# Altium schematic sheet inj_meas.SchDoc
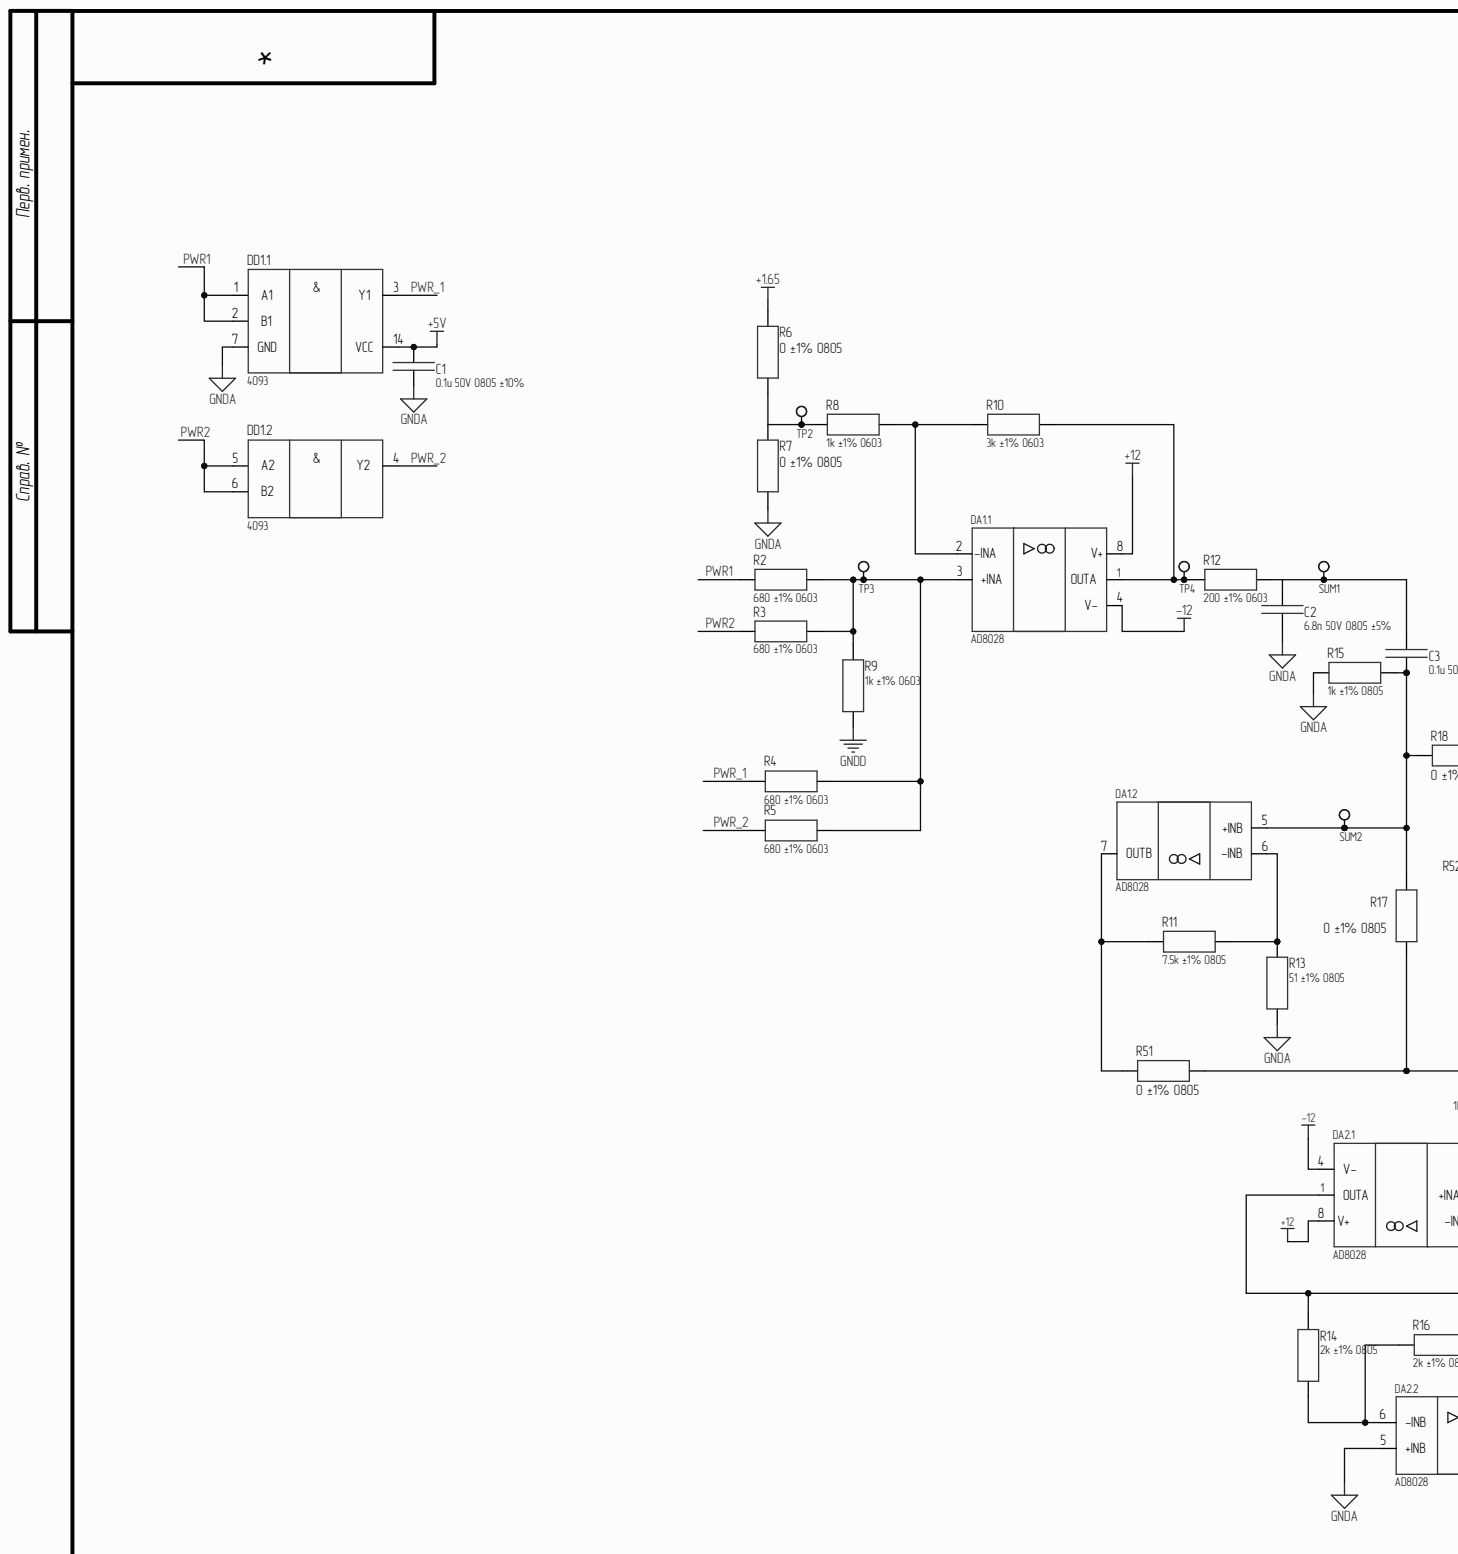
[diagram p0: inj_meas.SchDoc, top left]
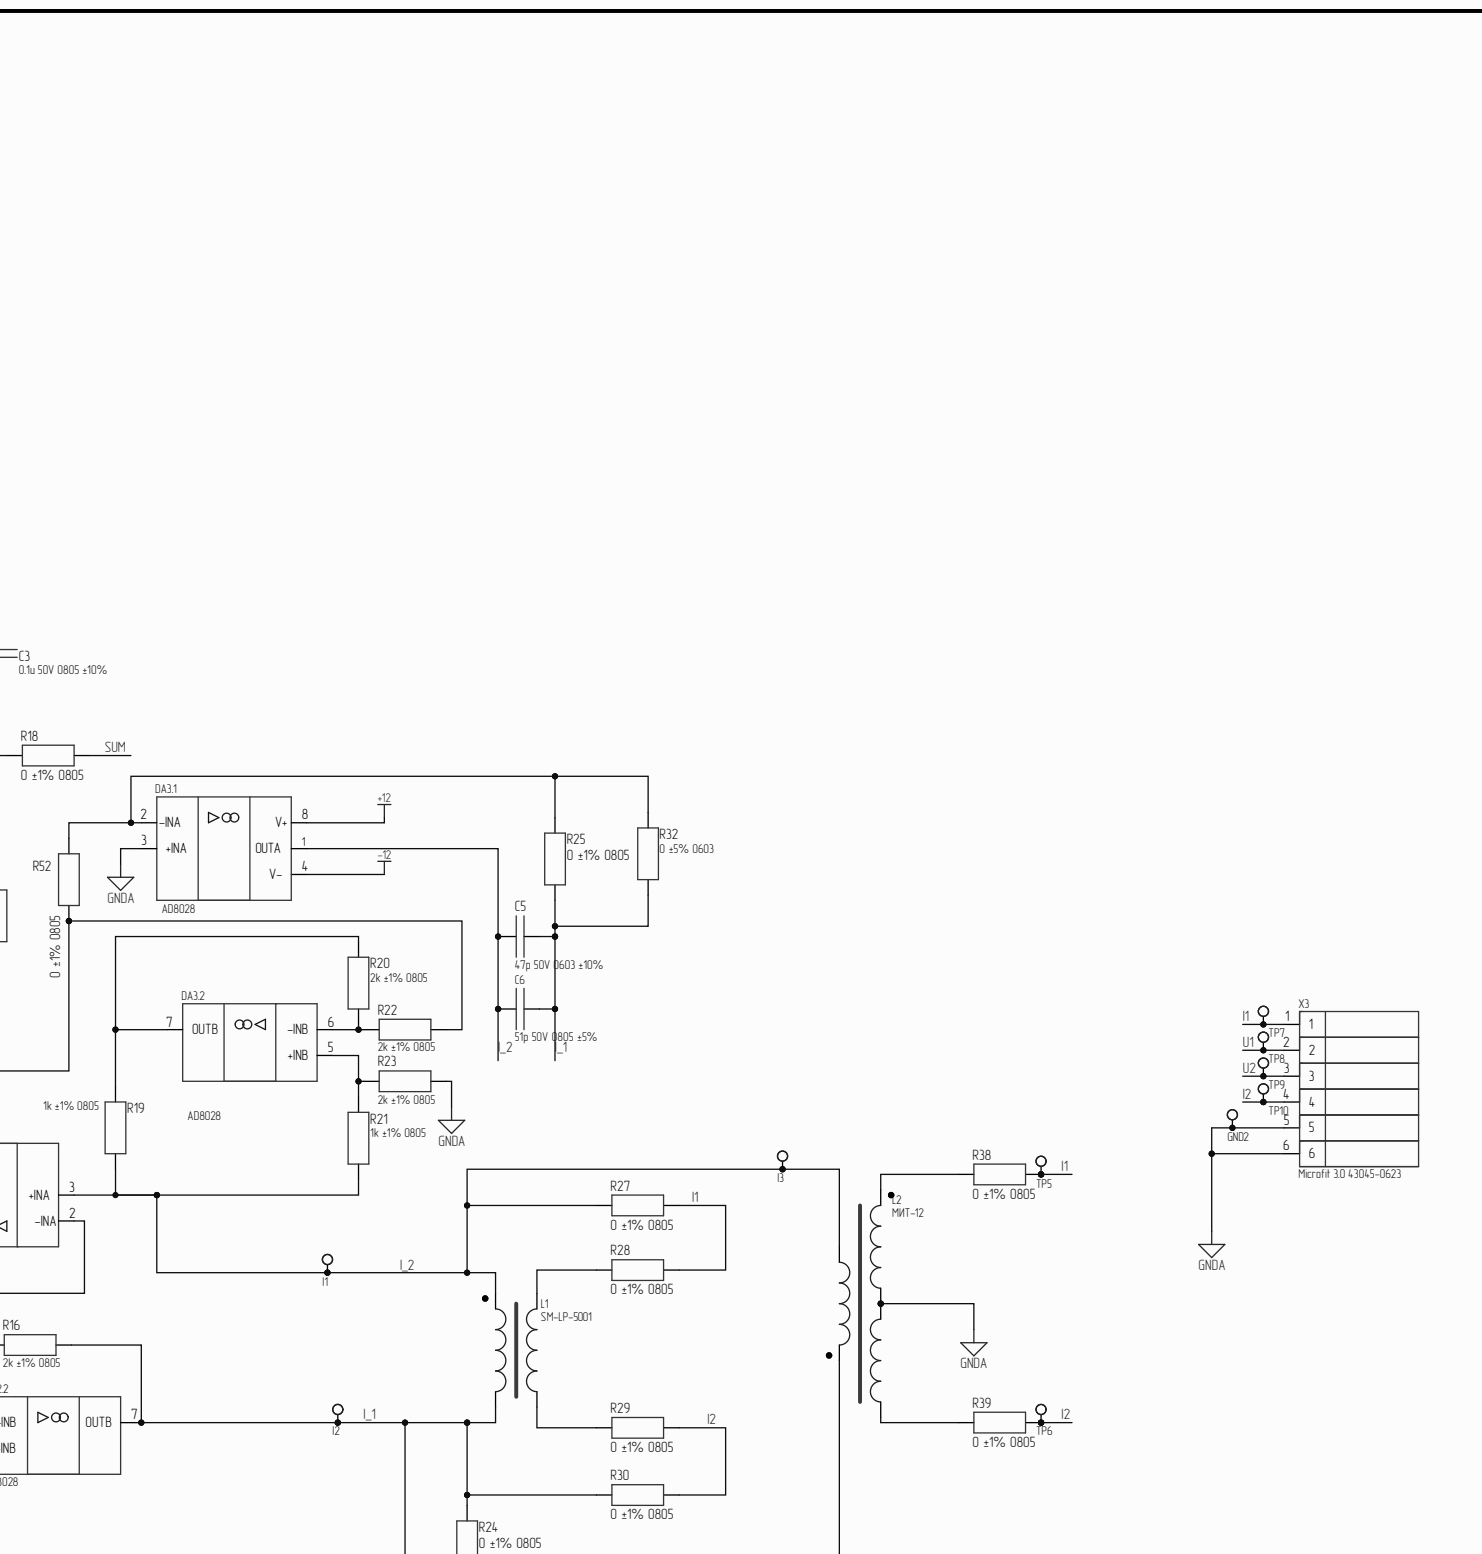
[diagram p1: inj_meas.SchDoc, top centre]
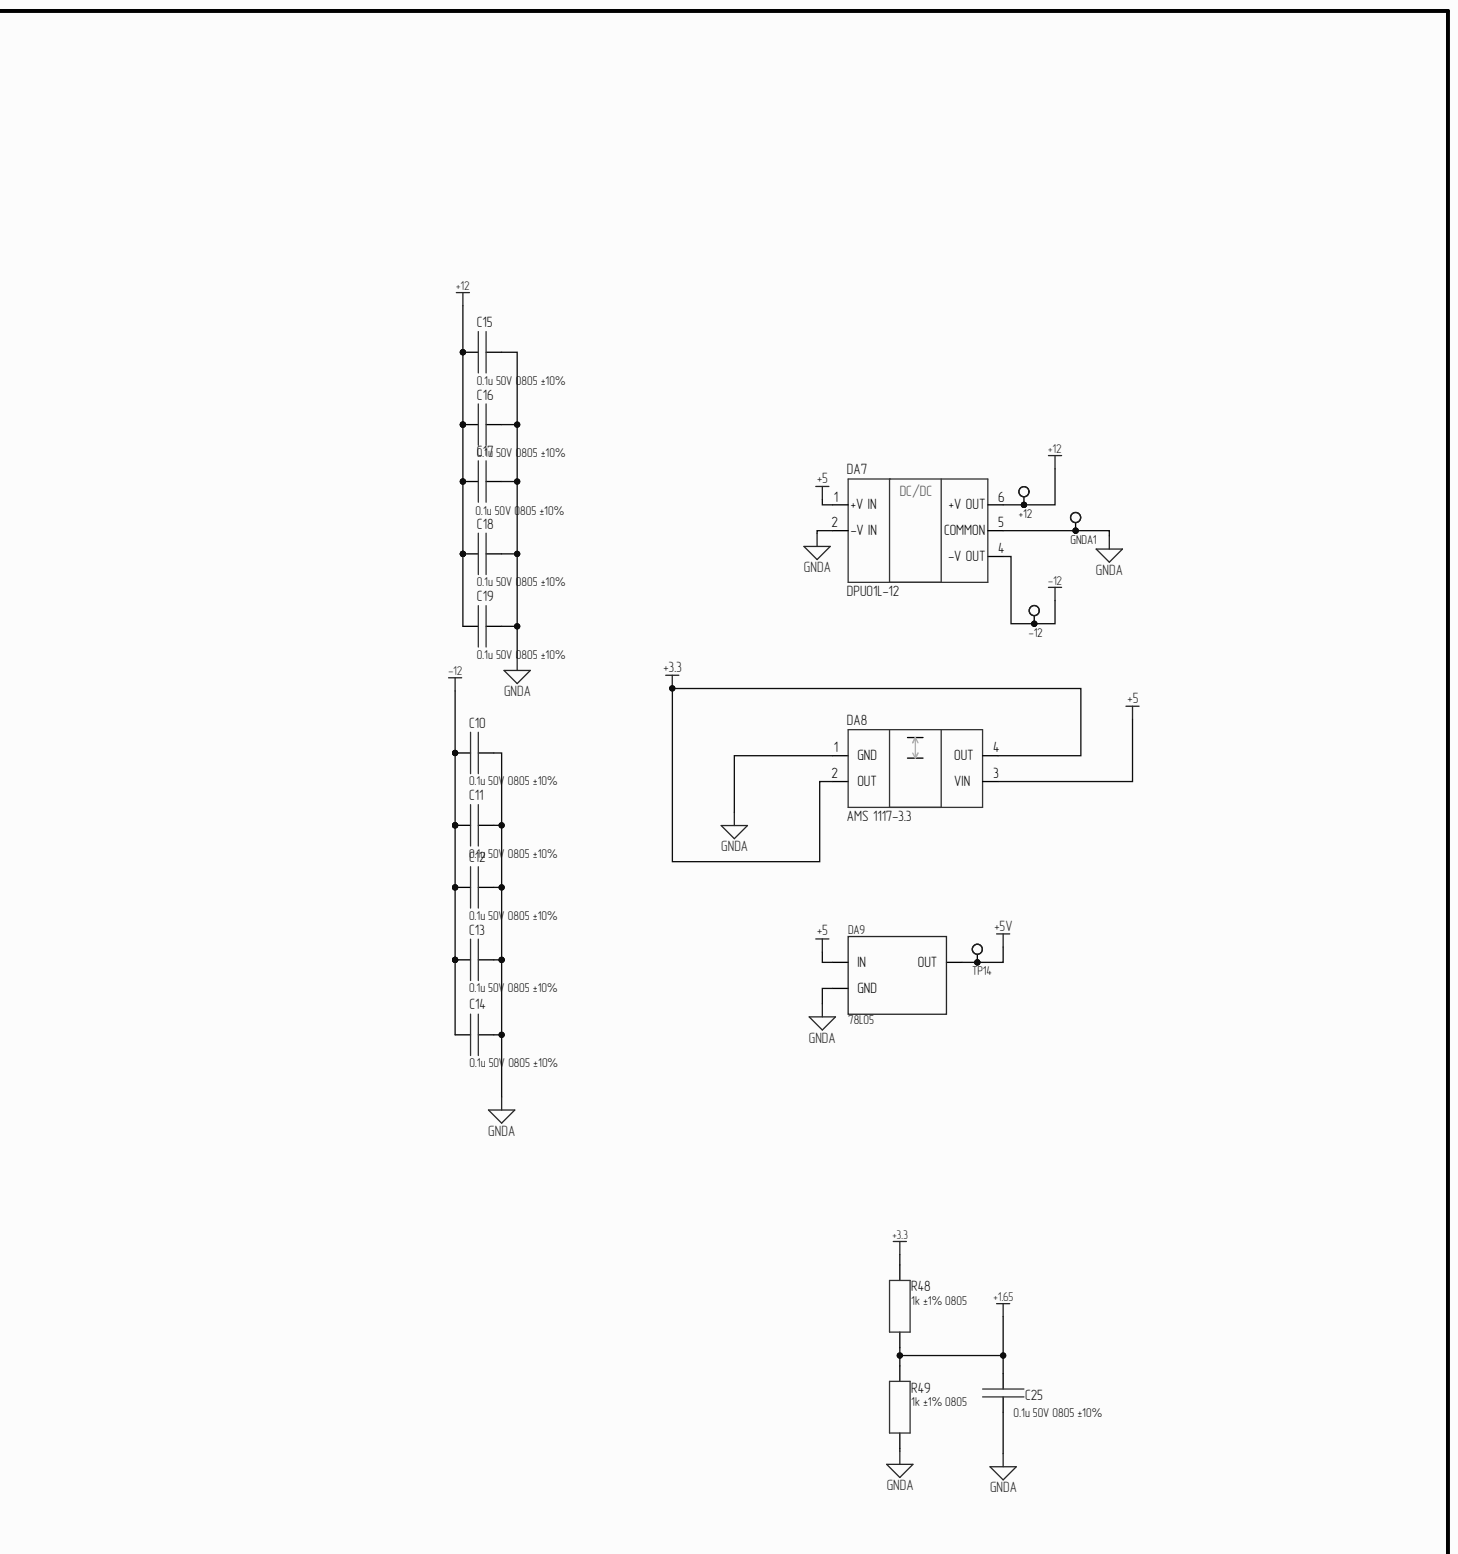
[diagram p2: inj_meas.SchDoc, top right]
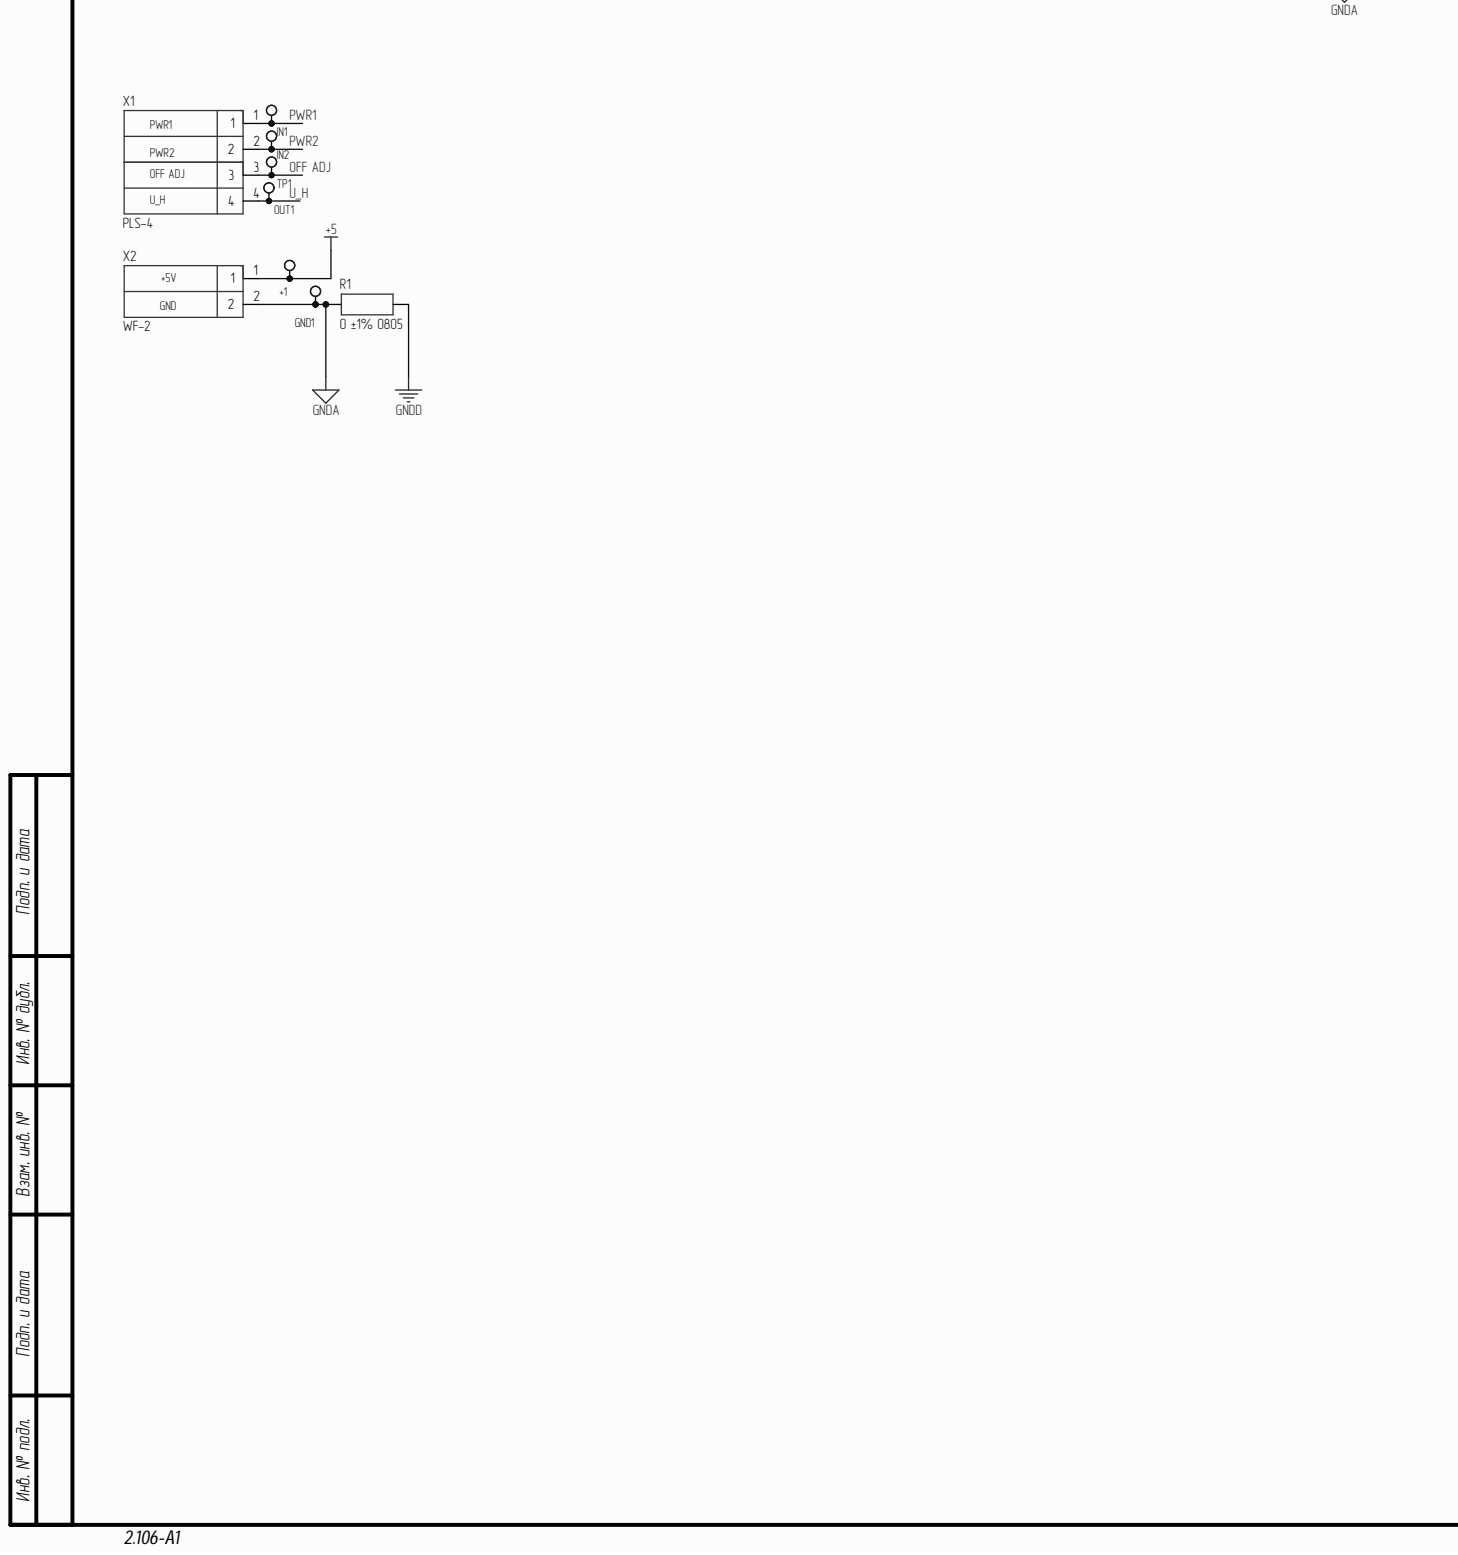
[diagram p3: inj_meas.SchDoc, bottom left]
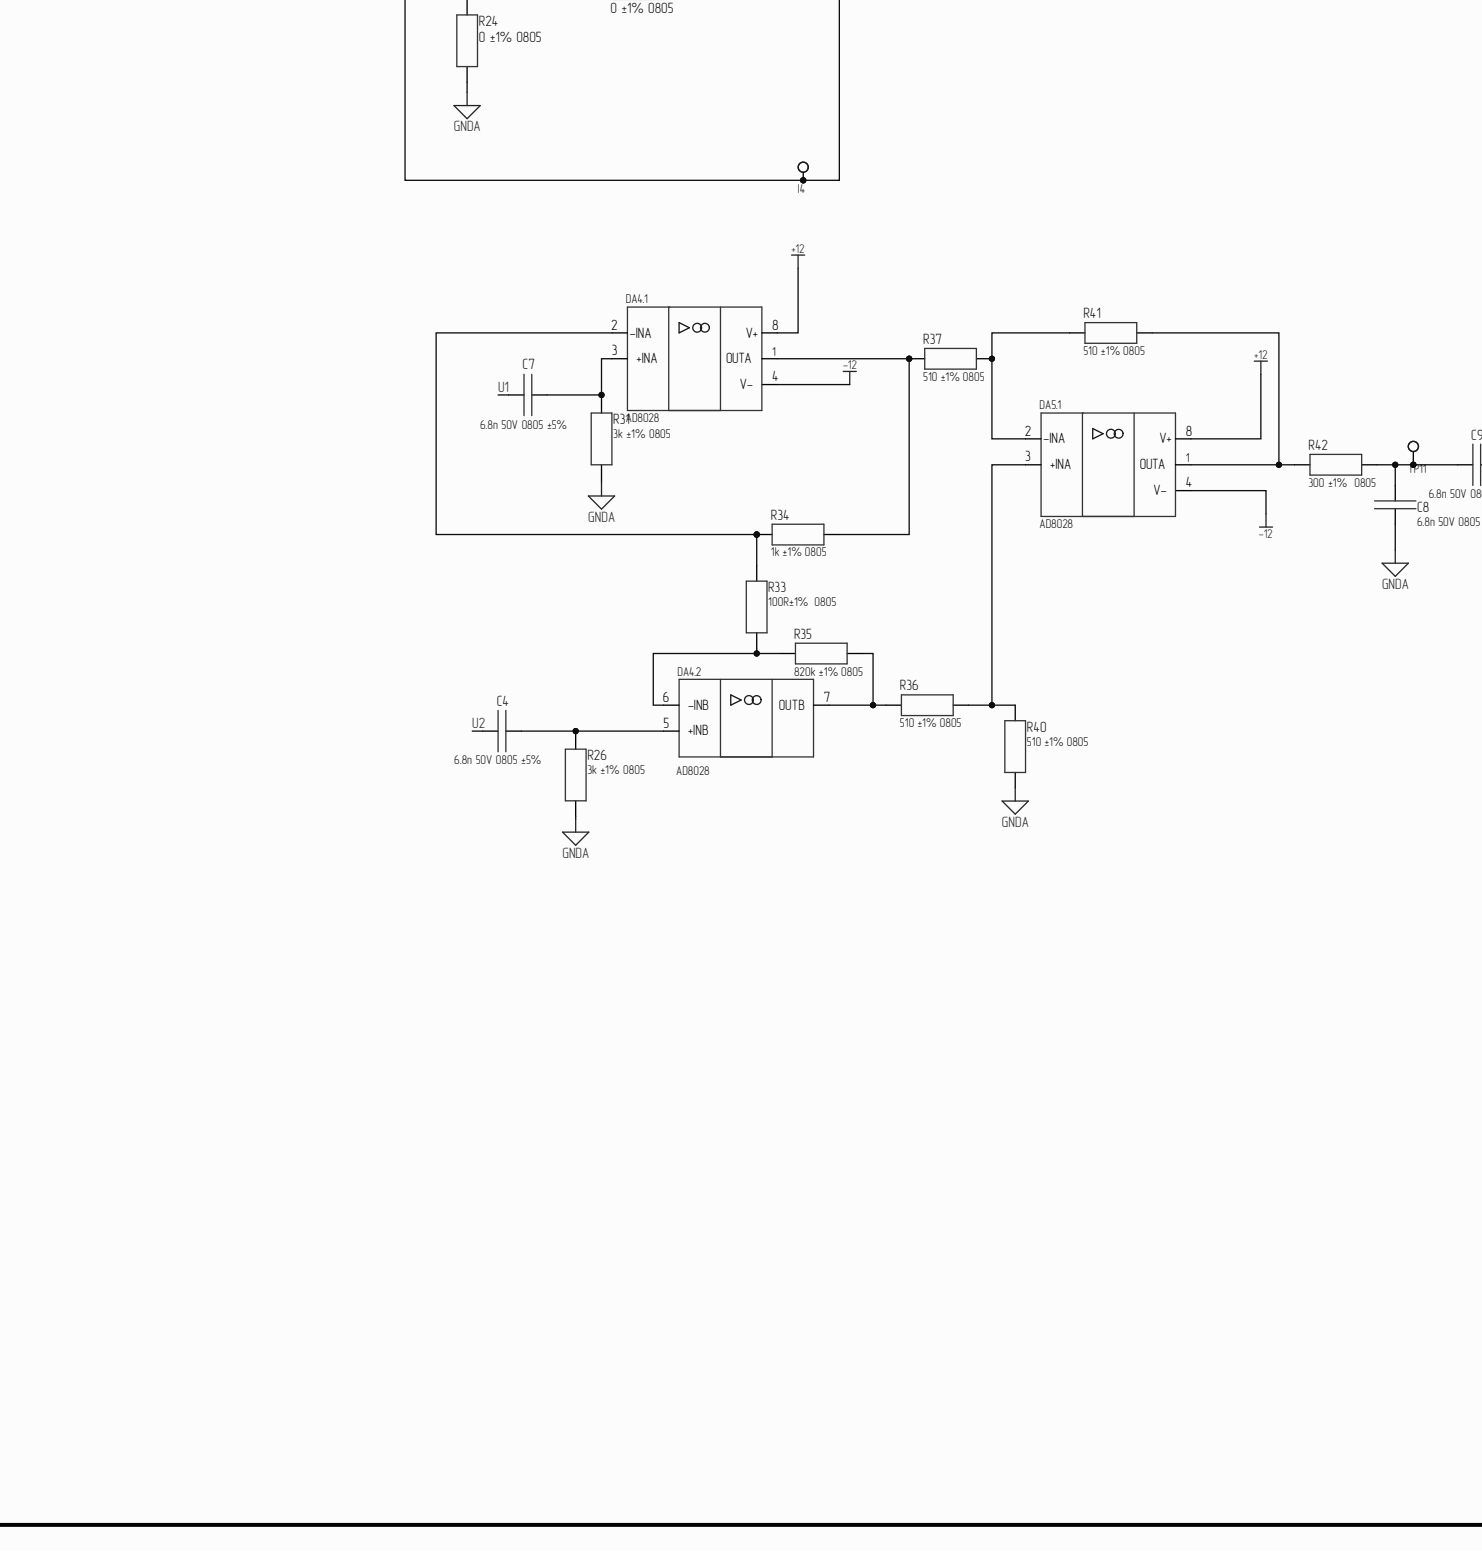
[diagram p4: inj_meas.SchDoc, bottom centre]
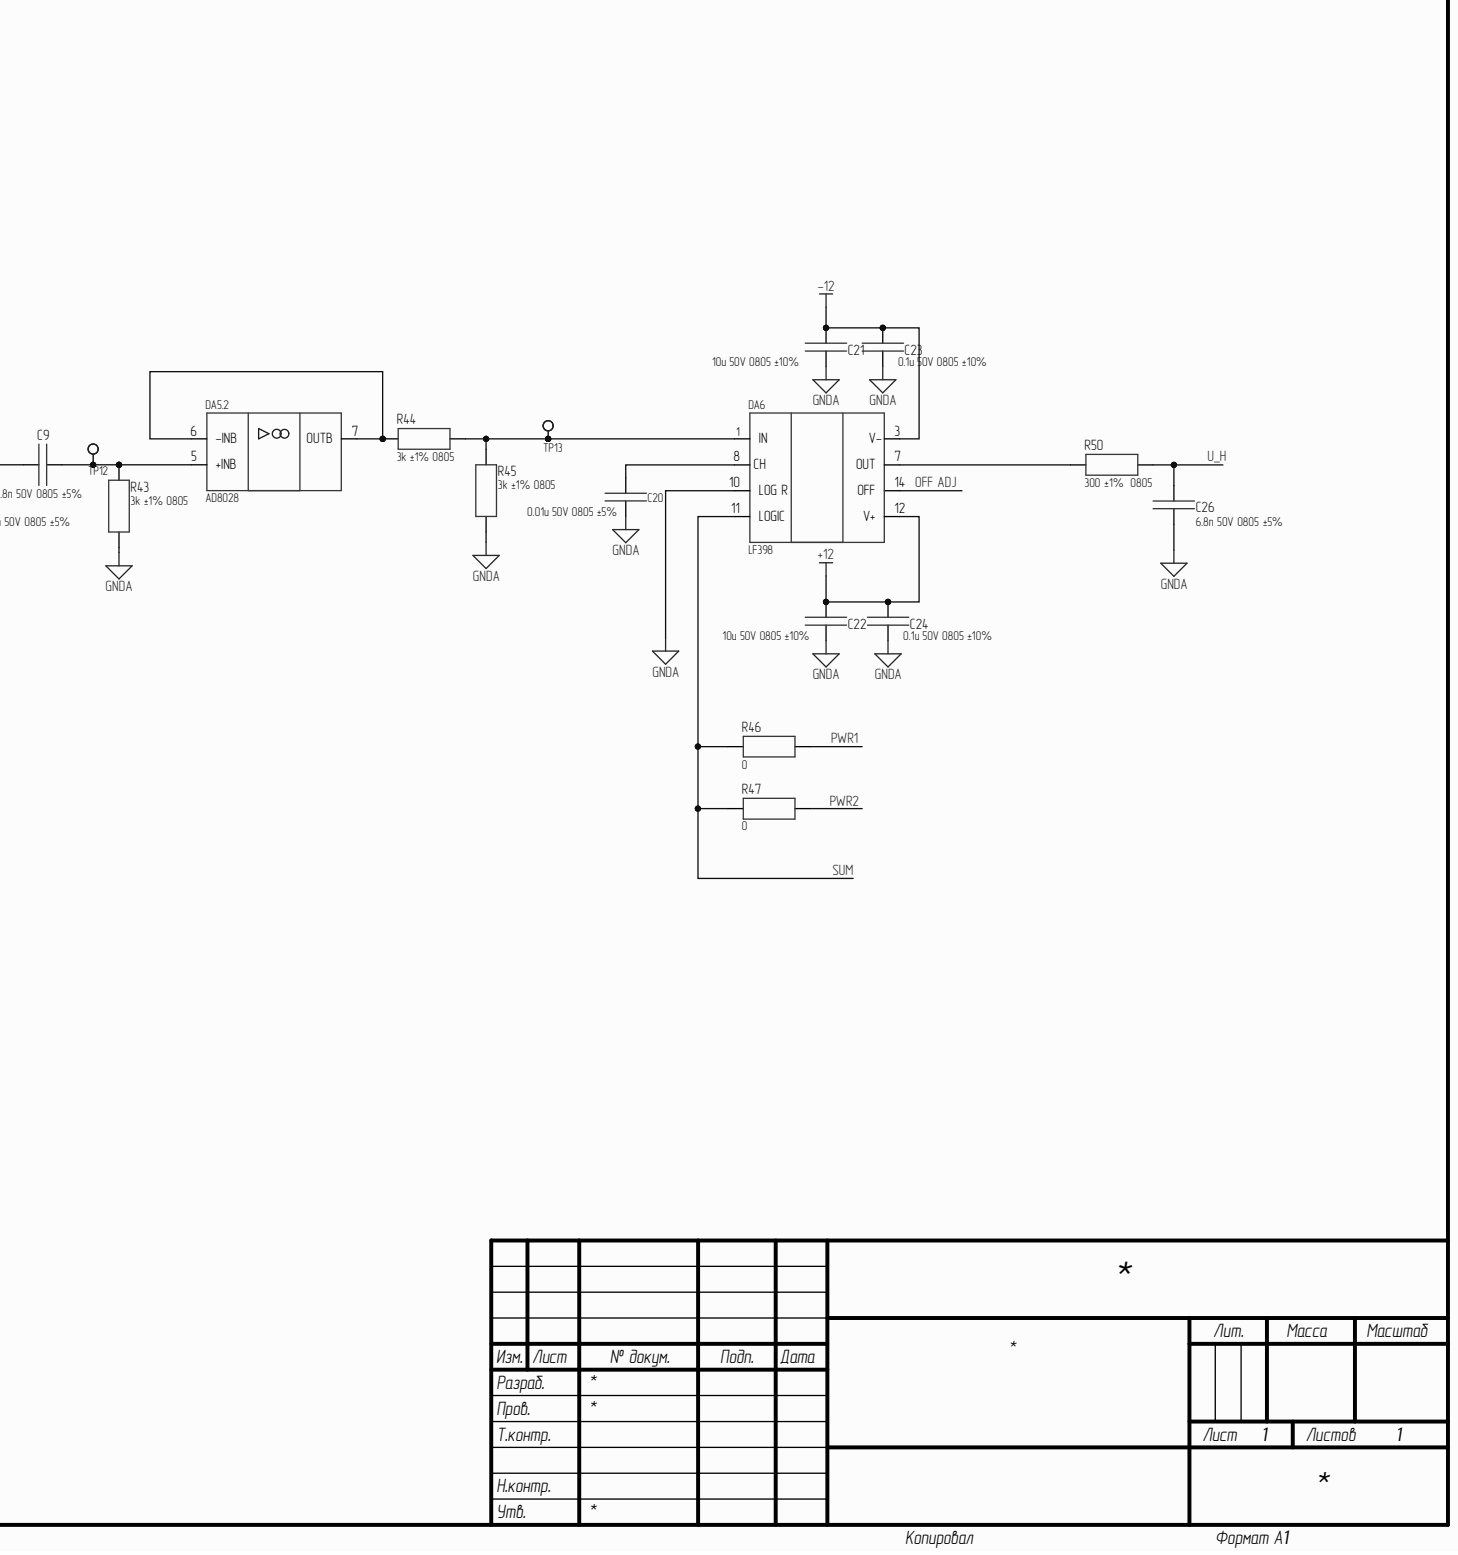
[diagram p5: inj_meas.SchDoc, bottom right]

## Parts
+1  Test point  [Test Point]  (value not drawn)  (net tie)
+12  Test point  [Test Point]  (value not drawn)  (net tie)
-12  Test point  [Test Point]  (value not drawn)  (net tie)
C1  0.1u 50V 0805 ±10%  [CAP: SMD 0805 MD IPC 782]
C2  6.8n 50V 0805 ±5%  [CAP: SMD 0805 MD IPC 782]
C3  0.1u 50V 0805 ±10%  [CAP: SMD 0805 MD IPC 782]
C4  6.8n 50V 0805 ±5%  [CAP: SMD 0805 MD IPC 782]
C5  47p 50V 0603 ±10%  [CAP: SMD 0603 MD IPC 782]
C6  51p 50V 0805 ±5%  [CAP: SMD 0805 MD IPC 782]
C7  6.8n 50V 0805 ±5%  [CAP: SMD 0805 MD IPC 782]
C8  6.8n 50V 0805 ±5%  [CAP: SMD 0805 MD IPC 782]
C9  6.8n 50V 0805 ±5%  [CAP: SMD 0805 MD IPC 782]
C10  0.1u 50V 0805 ±10%  [CAP: SMD 0805 MD IPC 782]
C11  0.1u 50V 0805 ±10%  [CAP: SMD 0805 MD IPC 782]
C12  0.1u 50V 0805 ±10%  [CAP: SMD 0805 MD IPC 782]
C13  0.1u 50V 0805 ±10%  [CAP: SMD 0805 MD IPC 782]
C14  0.1u 50V 0805 ±10%  [CAP: SMD 0805 MD IPC 782]
C15  0.1u 50V 0805 ±10%  [CAP: SMD 0805 MD IPC 782]
C16  0.1u 50V 0805 ±10%  [CAP: SMD 0805 MD IPC 782]
C17  0.1u 50V 0805 ±10%  [CAP: SMD 0805 MD IPC 782]
C18  0.1u 50V 0805 ±10%  [CAP: SMD 0805 MD IPC 782]
C19  0.1u 50V 0805 ±10%  [CAP: SMD 0805 MD IPC 782]
C20  0.01u 50V 0805 ±5%  [CAP: SMD 0805 MD IPC 782]
C21  10u 50V 0805 ±10%  [CAP: SMD 0805 MD IPC 782]
C22  10u 50V 0805 ±10%  [CAP: SMD 0805 MD IPC 782]
C23  0.1u 50V 0805 ±10%  [CAP: SMD 0805 MD IPC 782]
C24  0.1u 50V 0805 ±10%  [CAP: SMD 0805 MD IPC 782]
C25  0.1u 50V 0805 ±10%  [CAP: SMD 0805 MD IPC 782]
C26  6.8n 50V 0805 ±5%  [CAP: SMD 0805 MD IPC 782]
DA1  AD8028  [IC: SOIC 8]  (parts .1,.2)
DA2  AD8028  [IC: SOIC 8]  (parts .1,.2)
DA3  AD8028  [IC: SOIC 8]  (parts .1,.2)
DA4  AD8028  [IC: SOIC 8]  (parts .1,.2)
DA5  AD8028  [IC: SOIC 8]  (parts .1,.2)
DA6  LF398  [IC: SOIC 14]
DA7  DPU01L-12  [SIP-6]
DA8  AMS 1117-3.3  [IC: SOT 223]
DA9  78L05  [IC: SOT 89]
DD1  4093  [IC: SOIC 14]  (parts .1,.2)
GND1  Test point  [Test Point]  (value not drawn)  (net tie)
GND2  Test point  [Test Point]  (value not drawn)  (net tie)
GNDA1  Test point  [Test Point]  (value not drawn)  (net tie)
I1  Test point  [Test Point]  (value not drawn)  (net tie)
I2  Test point  [Test Point]  (value not drawn)  (net tie)
I3  Test point  [Test Point]  (value not drawn)  (net tie)
I4  Test point  [Test Point]  (value not drawn)  (net tie)
IN1  Test point  [Test Point]  (value not drawn)  (net tie)
IN2  Test point  [Test Point]  (value not drawn)  (net tie)
L1  SM-LP-5001  [IND: SM-LP-5001E]
L2  МИТ-12  [МИТ-12]
OUT1  Test point  [Test Point]  (value not drawn)  (net tie)
R1  0 ±1% 0805  [RES: SMD 0805 MD IPC 782]
R2  680 ±1% 0603  [RES: SMD 0603 MD IPC 782]
R3  680 ±1% 0603  [RES: SMD 0603 MD IPC 782]
R4  680 ±1% 0603  [RES: SMD 0603 MD IPC 782]
R5  680 ±1% 0603  [RES: SMD 0603 MD IPC 782]
R6  0 ±1% 0805  [RES: SMD 0805 MD IPC 782]
R7  0 ±1% 0805  [RES: SMD 0805 MD IPC 782]
R8  1k ±1% 0603  [RES: SMD 0603 MD IPC 782]
R9  1k ±1% 0603  [RES: SMD 0603 MD IPC 782]
R10  3k ±1% 0603  [RES: SMD 0603 MD IPC 782]
R11  7.5k ±1% 0805  [RES: SMD 0805 MD IPC 782]
R12  200 ±1% 0603  [RES: SMD 0603 MD IPC 782]
R13  51 ±1% 0805  [RES: SMD 0805 MD IPC 782]
R14  2k ±1% 0805  [RES: SMD 0805 MD IPC 782]
R15  1k ±1% 0805  [RES: SMD 0805 MD IPC 782]
R16  2k ±1% 0805  [RES: SMD 0805 MD IPC 782]
R17  0 ±1% 0805  [RES: SMD 0805 MD IPC 782]
R18  0 ±1% 0805  [RES: SMD 0805 MD IPC 782]
R19  1k ±1% 0805  [RES: SMD 0805 MD IPC 782]
R20  2k ±1% 0805  [RES: SMD 0805 MD IPC 782]
R21  1k ±1% 0805  [RES: SMD 0805 MD IPC 782]
R22  2k ±1% 0805  [RES: SMD 0805 MD IPC 782]
R23  2k ±1% 0805  [RES: SMD 0805 MD IPC 782]
R24  0 ±1% 0805  [RES: SMD 0805 MD IPC 782]
R25  0 ±1% 0805  [RES: SMD 0805 MD IPC 782]
R26  3k ±1% 0805  [RES: SMD 0805 MD IPC 782]
R27  0 ±1% 0805  [RES: SMD 0805 MD IPC 782]
R28  0 ±1% 0805  [RES: SMD 0805 MD IPC 782]
R29  0 ±1% 0805  [RES: SMD 0805 MD IPC 782]
R30  0 ±1% 0805  [RES: SMD 0805 MD IPC 782]
R31  3k ±1% 0805  [RES: SMD 0805 MD IPC 782]
R32  0 ±5% 0603  [RES: SMD 0603 MD IPC 782]
R33  100R±1%  0805  [RES: SMD 0805 MD IPC 782]
R34  1k ±1% 0805  [RES: SMD 0805 MD IPC 782]
R35  820k ±1% 0805  [RES: SMD 0805 MD IPC 782]
R36  510 ±1% 0805  [RES: SMD 0805 MD IPC 782]
R37  510 ±1% 0805  [RES: SMD 0805 MD IPC 782]
R38  0 ±1% 0805  [RES: SMD 0805 MD IPC 782]
R39  0 ±1% 0805  [RES: SMD 0805 MD IPC 782]
R40  510 ±1% 0805  [RES: SMD 0805 MD IPC 782]
R41  510 ±1% 0805  [RES: SMD 0805 MD IPC 782]
R42  300 ±1%  0805  [RES: SMD 0805 MD IPC 782]
R43  3k ±1% 0805  [RES: SMD 0805 MD IPC 782]
R44  3k ±1% 0805  [RES: SMD 0805 MD IPC 782]
R45  3k ±1% 0805  [RES: SMD 0805 MD IPC 782]
R46  0  [RES: SMD 0603 MD IPC 782]
R47  0  [RES: SMD 0603 MD IPC 782]
R48  1k ±1% 0805  [RES: SMD 0805 MD IPC 782]
R49  1k ±1% 0805  [RES: SMD 0805 MD IPC 782]
R50  300 ±1%  0805  [RES: SMD 0805 MD IPC 782]
R51  0 ±1% 0805  [RES: SMD 0805 MD IPC 782]
R52  0 ±1% 0805  [RES: SMD 0805 MD IPC 782]
SUM1  Test point  [Test Point]  (value not drawn)  (net tie)
SUM2  Test point  [Test Point]  (value not drawn)  (net tie)
TP1  Test point  [Test Point]  (value not drawn)  (net tie)
TP2  Test point  [Test Point]  (value not drawn)  (net tie)
TP3  Test point  [Test Point]  (value not drawn)  (net tie)
TP4  Test point  [Test Point]  (value not drawn)  (net tie)
TP5  Test point  [Test Point]  (value not drawn)  (net tie)
TP6  Test point  [Test Point]  (value not drawn)  (net tie)
TP7  Test point  [Test Point]  (value not drawn)  (net tie)
TP8  Test point  [Test Point]  (value not drawn)  (net tie)
TP9  Test point  [Test Point]  (value not drawn)  (net tie)
TP10  Test point  [Test Point]  (value not drawn)  (net tie)
TP11  Test point  [Test Point]  (value not drawn)  (net tie)
TP12  Test point  [Test Point]  (value not drawn)  (net tie)
TP13  Test point  [Test Point]  (value not drawn)  (net tie)
TP14  Test point  [Test Point]  (value not drawn)  (net tie)
X1  PLS-4  [CON: 4pin PLS-4]
X2  WF-2  [CON: 2pin wf-2]
X3  Microfit 3.0 43045-0623  [Molex Microfit 6pin]

## Nets
+1.65: C25.1 R6.2 R48.1 R49.2
+3.3: DA8.2(OUT) DA8.4(OUT) R48.2
+5: +1.1 DA7.1(+V_IN) DA8.3(VIN) DA9.3 X2.1
+5V: C1.1 DA9.1 DD1.14(VCC) TP14.1
+12: +12.1 C15.2 C16.2 C17.2 C18.2 C19.2 C22.1 C24.1 DA1.8(V+) DA2.8(V+) DA3.8(V+) DA4.8(V+) DA5.8(V+) DA6.12(V+) DA7.6(+V_OUT)
-12: -12.1 C10.2 C11.2 C12.2 C13.2 C14.2 C21.1 C23.1 DA1.4(V-) DA2.4(V-) DA3.4(V-) DA4.4(V-) DA5.4(V-) DA6.3(V-) DA7.4(-V_OUT)
GNDA: C1.2 C2.2 C8.2 C10.1 C11.1 C12.1 C13.1 C14.1 C15.1 C16.1 C17.1 C18.1 C19.1 C20.2 C21.2 C22.2 C23.2 C24.2 C25.2 C26.2 DA2.5(+INB) DA3.3(+INA) DA6.10(LOG_R) DA7.2(-V_IN) DA7.5(COMMON) DA8.1(GND) DA9.2 DD1.7(GND) GND1.1 GND2.1 GNDA1.1 L2.3 L2.6 R1.1 R7.1 R13.1 R15.1 R23.2 R24.1 R26.2 R31.2 R40.1 R43.2 R45.1 R49.1 X2.2 X3.5 X3.6
GNDD: R1.2 R9.1
I1: R27.2 R28.2 R38.2 TP5.1 TP7.1 X3.1
I2: R29.2 R30.2 R39.2 TP6.1 TP10.1 X3.4
I_1: C5.1 C6.1 DA2.7(OUTB) I2.1 I4.1 L1.3 L2.1 R16.2 R24.2 R25.1 R30.1 R32.1
I_2: C5.2 C6.2 DA2.3(+INA) DA3.1(OUTA) I1.1 I3.1 L1.1 L2.4 R19.2 R21.1 R27.1
OFF ADJ: DA6.14(OFF) TP1.1 X1.3
PWR1: DD1.1(A1) DD1.2(B1) IN1.1 R2.1 R46.2 X1.1
PWR2: DD1.5(A2) DD1.6(B2) IN2.1 R3.1 R47.2 X1.2
PWR_1: DD1.3(Y1) R4.1
PWR_2: DD1.4(Y2) R5.1
SUM: DA6.11(LOGIC) R18.2 R46.1 R47.1
U1: C7.2 TP8.1 X3.2
U2: C4.2 TP9.1 X3.3
U_H: C26.1 OUT1.1 R50.2 X1.4
NetC2_1: C2.1 C3.2 R12.2 SUM1.1
NetC3_1: C3.1 DA1.5(+INB) R15.2 R17.2 R18.1 SUM2.1
NetC4_1: C4.1 DA4.5(+INB) R26.1
NetC7_1: C7.1 DA4.3(+INA) R31.1
NetC8_1: C8.1 C9.2 R42.2 TP11.1
NetC9_1: C9.1 DA5.5(+INB) R43.1 TP12.1
NetC20_1: C20.1 DA6.8(CH)
NetDA1_1: DA1.1(OUTA) R10.2 R12.1 TP4.1
NetDA1_2: DA1.2(-INA) R8.2 R10.1
NetDA1_3: DA1.3(+INA) R2.2 R3.2 R4.2 R5.2 R9.2 TP3.1
NetDA1_6: DA1.6(-INB) R11.2 R13.2
NetDA1_7: DA1.7(OUTB) R11.1 R51.2
NetDA2_1: DA2.1(OUTA) DA2.2(-INA) R14.1
NetDA2_6: DA2.6(-INB) R14.2 R16.1
NetDA3_2: DA3.2(-INA) R25.2 R32.2 R52.2
NetDA3_5: DA3.5(+INB) R21.2 R23.1
NetDA3_6: DA3.6(-INB) R20.2 R22.1
NetDA3_7: DA3.7(OUTB) R19.1 R20.1
NetDA4_1: DA4.1(OUTA) R34.1 R37.1
NetDA4_2: DA4.2(-INA) R33.2 R34.2
NetDA4_6: DA4.6(-INB) R33.1 R35.2
NetDA4_7: DA4.7(OUTB) R35.1 R36.1
NetDA5_1: DA5.1(OUTA) R41.2 R42.1
NetDA5_2: DA5.2(-INA) R37.2 R41.1
NetDA5_3: DA5.3(+INA) R36.2 R40.2
NetDA5_6: DA5.6(-INB) DA5.7(OUTB) R44.1
NetDA6_1: DA6.1(IN) R44.2 R45.2 TP13.1
NetDA6_7: DA6.7(OUT) R50.1
NetL1_4: L1.4 R28.1
NetL1_6: L1.6 R29.1
NetL2_2: L2.2 R38.1
NetL2_5: L2.5 R39.1
NetR6_1: R6.1 R7.2 R8.1 TP2.1
NetR17_1: R17.1 R22.2 R51.1 R52.1

## Library data of the parts
C1 C3 C10-C19 C23-C25 = 0.1u 50V 0805 ±10%: Code: 6279254871; Name: Конденсатор X7R 50V 0,1uF ±10% 0805; Component Kind: Standard
  C1 C10-C19 C25: Library Name: UOMP capacitors.SchLib
  C3 C23 C24: Library Name: UOMP_capacitors.SchLib
C2 C4 C7-C9 C26 = 6.8n 50V 0805 ±5%: Code: 6279352311; Name: Конденсатор NPO 50V 6800pF ±5% 0805; Component Kind: Standard; Library Name: UOMP_capacitors.SchLib
C5 = 47p 50V 0603 ±10%: Code: 6279259841; Name: Конденсатор X7R 50V 0,047uF +-10% 0603; Component Kind: Standard; Library Name: UOMP_capacitors.SchLib
C6 = 51p 50V 0805 ±5%: Code: 6279351741; Name: Конденсатор NP0 50V 51pF±5% 0805; Component Kind: Standard; Library Name: UOMP_capacitors.SchLib
C20 = 0.01u 50V 0805 ±5%: Code: 6279261451; Name: Конденсатор X7R 50V  0,01uF±5%  0805; Component Kind: Standard; Library Name: UOMP_capacitors.SchLib
C21 C22 = 10u 50V 0805 ±10%: Code: 6289735441; Name: Конденсатор 12065D106KAT2A, AVX; Component Kind: Standard; Library Name: UOMP_capacitors.SchLib
DA1-DA5 = AD8028_part: Component Kind: Standard; Library Name: THE_BOYS_REO.SchLib
DA6 = LF398MX: Component Kind: Standard; Library Name: THE_BOYS_REO.SchLib
DA7 = DC-DC: +12/-12V DPU01L-12: Code: 6339113551; Name: Микросхема AM2D-0512DZ, AIMTEC; Component Kind: Standard; Library Name: UOMP_power.SchLib
DA8 = LDO: 15-3.3V AMS1117: Code: 6339112951; Name: Микросхема AMS-1117-3.3; Component Kind: Standard; Library Name: UOMP_power.SchLib
DA9 = 78L05: 78L05, Стабилизатор напряжения +5В 0.1А; P/N: Микросхема питания 78L05, UMW; Component Kind: Standard; ComponentLink1Description: Chipdip; ComponentLink1URL: https://www.chipdip.ru/product/78l05-stabilizator-napryazheniya-5v-0.1a-sot-89-umw-9001231082; Library Name: Metal_Opera_Power.SchLib
DD1 = LOGIC: 4093: &; Code: 6330002931; Name: Микросхема IW4093BD, ТУ РБ 14513714.005-03-95; Component Kind: Standard; Library Name: UOMP_IC.SchLib
R1 R6 R7 R17 R18 R24 R25 R27-R30 R38 R39 R51 R52 = 0 ±1% 0805: Code: 6094342239; Name: Резистор 0R ±1% 0805; Component Kind: Standard; Library Name: UOMP_resistors.SchLib
R2-R5 = 680 ±1% 0603: Code: 6069180761; Name: Резистор 680R ±1% 0603; Component Kind: Standard; Library Name: UOMP_resistors.SchLib
R8 R9 = 1k ±1% 0603: Code: 6069174188; Name: Резистор 1k ±1% 0603; Component Kind: Standard; Library Name: UOMP_resistors.SchLib
R10 = 3k ±1% 0603: Code: 6094357331; Name: Резистор 3К ±1% 0603; Component Kind: Standard; Library Name: UOMP_resistors.SchLib
R11 = 7.5k ±1% 0805: Code: 6094357441; Name: Резистор 7,5k±1% 0805; Component Kind: Standard; Library Name: UOMP_resistors.SchLib
R12 = 200 ±1% 0603: Code: 6069180621; Name: Резистор 200R±1% 0603; Component Kind: Standard; Library Name: UOMP_resistors.SchLib
R13 = 51 ±1% 0805: Code: 6094354057; Name: Резистор 51K±1% 0805; Component Kind: Standard; Library Name: UOMP_resistors.SchLib
R14 R16 R20 R22 R23 = 2k ±1% 0805: Code: 6094352561; Name: Резистор 2k±1%  0805; Component Kind: Standard; Library Name: UOMP resistors.SchLib
R15 R19 R21 R34 R48 R49 = 1k ±1% 0805: Code: 6027158691; Name: Резистор RC0805FR-071KL, Yageo; Component Kind: Standard
  R15 R34: Library Name: UOMP_resistors.SchLib
  R19 R21 R48 R49: Library Name: UOMP resistors.SchLib
R26 R31 R43-R45 = 3k ±1% 0805: Code: 6094357331; Name: Резистор 3К ±1% 0805; Component Kind: Standard; Library Name: UOMP_resistors.SchLib
R32 = 0 ±5% 0603: Code: 6020004221; Name: Резистор RC0603JR-070RL, Yageo; Component Kind: Standard; Library Name: UOMP_resistors.SchLib
R33 = 100 ±1%  0805: Code: 6094351171; Name: Резистор 100R±1%  0805; Component Kind: Standard; Library Name: UOMP_resistors.SchLib
R35 = 820k ±1% 0805: Code: 6019041741; Name: Резистор RC0805FR-07820KL, Yageo; Component Kind: Standard; Library Name: UOMP_resistors.SchLib
R36 R37 R40 R41 = 510 ±1% 0805: Code: 6069137191; Name: Резистор 510R±1%  0805; Component Kind: Standard; Library Name: UOMP_resistors.SchLib
R42 R50 = 300 ±1%  0805: Code: 6094357025; Name: Резистор 300R±1%  0805; Component Kind: Standard; Library Name: UOMP_resistors.SchLib
R46 R47 = 0 ±5%: Code: 6020004221; Name: Резистор RC0603JR-070RL, Yageo; Component Kind: Standard; Library Name: UOMP_resistors_0603.SchLib
X1 = 4 pin PLS-4: Code: 6313932041; Name: Вилка WF-4; Component Kind: Standard; Library Name: UOMP_connectors.SchLib
X2 = 2 pin WF-2: Code: 6313952902; Name: Вилка WF-2; Component Kind: Standard; Library Name: UOMP_connectors.SchLib
X3 = 6 pin Microfit: Microfit 3.0 43045-0623 6 pin угловой разъем; Component Kind: Standard; Library Name: Metal_Opera_Connectors.SchLib
L1 = SM-LP-5001: Component Kind: Standard; Library Name: THE_BOYS_REO.SchLib
L2 = МИТ-12: Component Kind: Standard; Library Name: Metal_Opera_Inductors.SchLib
+1 +12 -12 GND1 GND2 GNDA1 I1-I4 IN1 IN2 OUT1 SUM1 SUM2 TP1-TP14 = Test point: Library Name: UOMP_primitives.SchLib
